# Altium schematic sheet MCU_Debug_COM_Extension.SchDoc(MCU_Debug_COM_Extension)
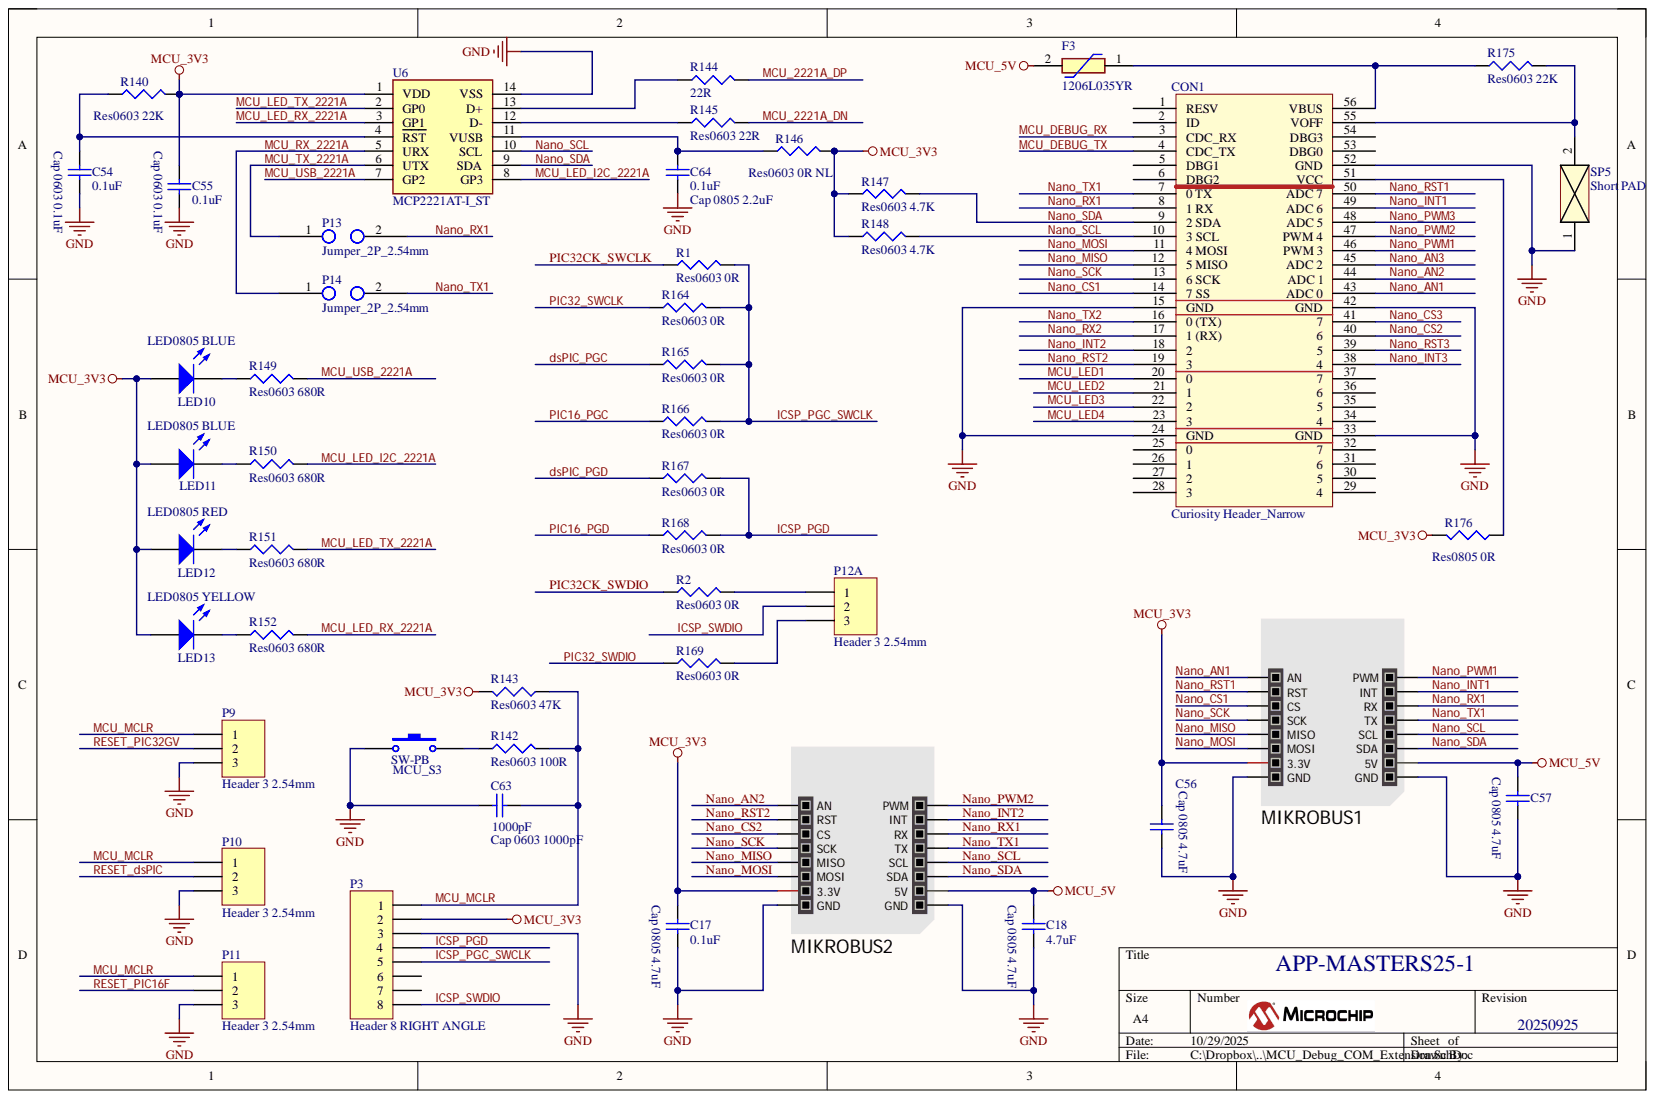
[diagram p0: MCU_Debug_COM_Extension.SchDoc]

## Parts
C17  Cap 0805 4.7uF  value 0.1uF  [C0805]
C18  Cap 0805 4.7uF  value 4.7uF  [C0805]
C54  Cap 0603 0.1uF  value 0.1uF  [0603C]
C55  Cap 0603 0.1uF  value 0.1uF  [0603C]
C56  Cap 0805 4.7uF  value 4.7uF  [C0805]
C57  Cap 0805 4.7uF  value 4.7uF  [C0805]
C63  Cap 0603 1000pF  value 1000pF  [0603C]
C64  Cap 0805 2.2uF  value 0.1uF  [C0805]
CON1  Curiosity Header_Narrow  [Curiosity_Nano_Footprint_Narrow]
F3  1206L035YR  [1206L05015YR]
LED10  LED0805 BLUE  [LED0805_B]
LED11  LED0805 BLUE  [LED0805_B]
LED12  LED0805 RED  [LED0805_R]
LED13  LED0805 YELLOW  [LED0805_Y]
MCU_S3  SW-PB  [Button_SMD]
MIKROBUS1  MIKROBUS HOST CONN._1  [MIKROBUS HOST CONN.]  (value not drawn)
MIKROBUS2  MIKROBUS HOST CONN._1  [MIKROBUS HOST CONN.]  (value not drawn)
P3  Header 8 RIGHT ANGLE  [HEADER-08-RA]
P9  Header 3 2.54mm  [HDR1X3]
P10  Header 3 2.54mm  [HDR1X3]
P11  Header 3 2.54mm  [HDR1X3]
P12A  Header 3 2.54mm  [HDR1X3]
P13  Jumper_2P_2.54mm  [HDR1X2]
P14  Jumper_2P_2.54mm  [HDR1X2]
R1  Res0603 0R  value 0R  [0603R]
R2  Res0603 0R  value 0R  [0603R]
R140  Res0603 22K  value 22K  [0603R]
R142  Res0603 100R  value 100R  [0603R]
R143  Res0603 47K  value 47K  [0603R]
R144  Res0603 22R  value 22R  [0603R]
R145  Res0603 22R  value 22R  [0603R]
R146  Res0603 0R NL  value 0R NL  [0603R]
R147  Res0603 4.7K  value 4.7K  [0603R]
R148  Res0603 4.7K  value 4.7K  [0603R]
R149  Res0603 680R  [0603R]
R150  Res0603 680R  [0603R]
R151  Res0603 680R  [0603R]
R152  Res0603 680R  [0603R]
R164  Res0603 0R  value 0R  [0603R]
R165  Res0603 0R  value 0R  [0603R]
R166  Res0603 0R  value 0R  [0603R]
R167  Res0603 0R  value 0R  [0603R]
R168  Res0603 0R  value 0R  [0603R]
R169  Res0603 0R  value 0R  [0603R]
R175  Res0603 22K  value 22K  [0603R]
R176  Res0805 0R  value 0R  [RES0805]
SP5  Short PAD  [Short_PAD]
U6  MCP2221AT-I_ST  [SOP65P640X120-14N]

## Nets
GND: C17.1 C18.1 C54.1 C55.1 C56.1 C57.1 C63.1 C64.1 CON1.15(GND) CON1.24(GND) CON1.33(GND) CON1.42(GND) CON1.52(GND) MCU_S3.1 MIKROBUS1.8(GND) MIKROBUS1.9(GND) MIKROBUS2.8(GND) MIKROBUS2.9(GND) P3.3 P9.3 P10.3 P11.3 SP5.1 U6.14(VSS)
MCU_3V3: C17.2 C55.2 C56.2 LED10.1 LED11.1 LED12.1 LED13.1 MIKROBUS1.7(3.3V) MIKROBUS2.7(3.3V) P3.2 R140.2 R143.2 R146.2 R147.1 R148.1 R176.2 U6.1(VDD)
MCU_5V: C18.2 C57.2 F3.2 MIKROBUS1.10(5V) MIKROBUS2.10(5V)
dsPIC_PGC: R165.1
dsPIC_PGD: R167.1
ICSP_PGC_SWCLK: P3.5 R1.2 R164.2 R165.2 R166.2
ICSP_PGD: P3.4 R167.2 R168.2
ICSP_SWDIO: P3.8 P12A.2
MCU_2221A_DN: R145.2
MCU_2221A_DP: R144.2
MCU_DEBUG_RX: CON1.3(CDC_RX)
MCU_DEBUG_TX: CON1.4(CDC_TX)
MCU_LED1: CON1.20
MCU_LED2: CON1.21
MCU_LED3: CON1.22
MCU_LED4: CON1.23
MCU_LED_I2C_2221A: R150.2 U6.8(GP3)
MCU_LED_RX_2221A: R152.2 U6.3(GP1)
MCU_LED_TX_2221A: R151.2 U6.2(GP0)
MCU_MCLR: C63.2 P3.1 P9.1 P10.1 P11.1 R142.2 R143.1
MCU_RX_2221A: P14.1 U6.5(URX)
MCU_TX_2221A: P13.1 U6.6(UTX)
MCU_USB_2221A: R149.2 U6.7(GP2)
Nano_AN1: CON1.43(ADC_0) MIKROBUS1.1(AN)
Nano_AN2: CON1.44(ADC_1) MIKROBUS2.1(AN)
Nano_AN3: CON1.45(ADC_2)
Nano_CS1: CON1.14(7_SS) MIKROBUS1.3(CS)
Nano_CS2: CON1.40 MIKROBUS2.3(CS)
Nano_CS3: CON1.41
Nano_INT1: CON1.49(ADC_6) MIKROBUS1.15(INT)
Nano_INT2: CON1.18 MIKROBUS2.15(INT)
Nano_INT3: CON1.38
Nano_MISO: CON1.12(5_MISO) MIKROBUS1.5(MISO) MIKROBUS2.5(MISO)
Nano_MOSI: CON1.11(4_MOSI) MIKROBUS1.6(MOSI) MIKROBUS2.6(MOSI)
Nano_PWM1: CON1.46(PWM_3) MIKROBUS1.16(PWM)
Nano_PWM2: CON1.47(PWM_4) MIKROBUS2.16(PWM)
Nano_PWM3: CON1.48(ADC_5)
Nano_RST1: CON1.50(ADC_7) MIKROBUS1.2(RST)
Nano_RST2: CON1.19 MIKROBUS2.2(RST)
Nano_RST3: CON1.39
Nano_RX1: CON1.8(1_RX) MIKROBUS1.14(RX) MIKROBUS2.14(RX) P13.2
Nano_RX2: CON1.17(1_(RX))
Nano_SCK: CON1.13(6_SCK) MIKROBUS1.4(SCK) MIKROBUS2.4(SCK)
Nano_SCL: CON1.10(3_SCL) MIKROBUS1.12(SCL) MIKROBUS2.12(SCL) R148.2 U6.10(SCL)
Nano_SDA: CON1.9(2_SDA) MIKROBUS1.11(SDA) MIKROBUS2.11(SDA) R147.2 U6.9(SDA)
Nano_TX1: CON1.7(0_TX) MIKROBUS1.13(TX) MIKROBUS2.13(TX) P14.2
Nano_TX2: CON1.16(0_(TX))
PIC16_PGC: R166.1
PIC16_PGD: R168.1
PIC32_SWCLK: R164.1
PIC32_SWDIO: R169.1
PIC32CK_SWCLK: R1.1
PIC32CK_SWDIO: R2.1
RESET_dsPIC: P10.2
RESET_PIC16F: P11.2
RESET_PIC32GV: P9.2
NetC54_2: C54.2 R140.1 U6.4(RST)
NetC64_2: C64.2 R146.1 U6.11(VUSB)
NetCON1_51: CON1.51(VCC) R176.1
NetCON1_55: CON1.55(VOFF) R175.2 SP5.2
NetCON1_56: CON1.56(VBUS) F3.1 R175.1
NetLED10_2: LED10.2 R149.1
NetLED11_2: LED11.2 R150.1
NetLED12_2: LED12.2 R151.1
NetLED13_2: LED13.2 R152.1
NetMCU_S3_2: MCU_S3.2 R142.1
NetP12A_1: P12A.1 R2.2
NetP12A_3: P12A.3 R169.2
NetR144_1: R144.1 U6.13(D+)
NetR145_1: R145.1 U6.12(D-)

Not connected: CON1 1(RESV) 2(ID) 5(DBG1) 6(DBG2) 25 26 27 28 29 30 31 32 34 35 36 37 53(DBG0) 54(DBG3); P3 6 7

## Library data of the parts
C17 C18 C56 C57 C64 = Cap 0805: Capacitor (Semiconductor SIM Model); Component Kind: Standard; LatestRevisionDate: 29-May-2009; LatestRevisionNote: IPC-7351 Footprint Added.; Library Name: MCHP_Parts_2016.SchLib; PackageDescription: Chip Capacitor; PackageReference: C1206; Published: 8-Jun-2000; Publisher: Altium Limited
C54 C55 C63 = Cap 0603: Capacitor (Semiconductor SIM Model); Component Kind: Standard; LatestRevisionDate: 29-May-2009; LatestRevisionNote: IPC-7351 Footprint Added.; Library Name: MCHP_Parts_2016.SchLib; PackageDescription: Chip Capacitor; PackageReference: C0603; Published: 8-Jun-2000; Publisher: Altium Limited
F3 = 1206L035YR: Resettable Fuse; Manufacturer_Name: LITTELFUSE; Arrow Part Number: 1206L035YR; Arrow Price/Stock: https://www.arrow.com/en/products/1206l035yr/littelfuse?region=nac; Component Kind: Standard; Datasheet Link: https://m.littelfuse.com/~/media/electronics/datasheets/resettable_ptcs/littelfuse_ptc_1206l_datasheet.pdf.pdf; Height: 0.75mm; Library Name: SamacSys.SchLib; Manufacturer_Part_Number: 1206L035YR; Mouser Part Number: 576-1206L035YR; Mouser Price/Stock: https://www.mouser.co.uk/ProductDetail/Littelfuse/1206L035YR?qs=PWhpLWeW8wd%2F%252B9d2B1EXww%3D%3D
LED10 LED11 = LED0805 BLUE: Typical BLUE SiC LED; Component Kind: Standard; DatasheetVersion: 16-Apr-2002; LatestRevisionDate: 17-Dec-2003; LatestRevisionNote: PCB Footprint replaced.; Library Name: MCHP_Parts_2016.SchLib; PackageDescription: SM LED; 2 C-Bend Leads; Body 3.5 x 2.8 x 1.9 mm, inc leads (LxWxH typ); PackageReference: 3.5X2.8X1.9; PackageVersion: 16-Apr-2002; Published: 8-Jun-2000; Publisher: Altium Limited
LED12 = LED0805 RED: Typical BLUE SiC LED; Component Kind: Standard; DatasheetVersion: 16-Apr-2002; LatestRevisionDate: 17-Dec-2003; LatestRevisionNote: PCB Footprint replaced.; Library Name: MCHP_Parts_2016.SchLib; PackageDescription: SM LED; 2 C-Bend Leads; Body 3.5 x 2.8 x 1.9 mm, inc leads (LxWxH typ); PackageReference: 3.5X2.8X1.9; PackageVersion: 16-Apr-2002; Published: 8-Jun-2000; Publisher: Altium Limited
LED13 = LED0805 YELLOW: Typical BLUE SiC LED; Component Kind: Standard; DatasheetVersion: 16-Apr-2002; LatestRevisionDate: 17-Dec-2003; LatestRevisionNote: PCB Footprint replaced.; Library Name: MCHP_Parts_2016.SchLib; PackageDescription: SM LED; 2 C-Bend Leads; Body 3.5 x 2.8 x 1.9 mm, inc leads (LxWxH typ); PackageReference: 3.5X2.8X1.9; PackageVersion: 16-Apr-2002; Published: 8-Jun-2000; Publisher: Altium Limited
MCU_S3 = SW-PB: Switch; Component Kind: Standard; LatestRevisionDate: 17-Jul-2002; LatestRevisionNote: Re-released for DXP Platform.; Library Name: MCHP_Parts_2016.SchLib; PackageDescription: Switch; 2 Leads; PackageReference: SPST-2; Published: 24-Mar-1999; Publisher: Altium Limited
MIKROBUS1 MIKROBUS2 = MIKROBUS HOST CONN._1: mikroBUS Host Socket; Component Kind: Standard; Library Name: MCHP_Parts_2016.SchLib; SMD: No
P3 = Header 8 RA: Header, 8-Pin; Component Kind: Standard; LatestRevisionDate: 17-Jul-2002; LatestRevisionNote: Re-released for DXP Platform.; Library Name: MCHP_Parts_2016.SchLib; Publisher: Altium Limited
P9-P11 P12A = Header 3: Header, 3-Pin; Component Kind: Standard; LatestRevisionDate: 17-Jul-2002; LatestRevisionNote: Re-released for DXP Platform.; Library Name: MCHP_Parts_2016.SchLib; Publisher: Altium Limited
R1 R2 R140 R142-R148 R164-R169 R175 = Res0603: Resistor; Component Kind: Standard; LatestRevisionDate: 29-May-2009; LatestRevisionNote: IPC-7351 Footprint Added.; Library Name: MCHP_Parts_2016.SchLib; PackageDescription: Chip Resistor; PackageReference: J1-0603; Published: 8-Jun-2000; Publisher: Altium Limited
R149-R152 = Res0603: Resistor; Value: 680; Component Kind: Standard; LatestRevisionDate: 29-May-2009; LatestRevisionNote: IPC-7351 Footprint Added.; Library Name: MCHP_Parts_2016.SchLib; PackageDescription: Chip Resistor; PackageReference: J1-0603; Published: 8-Jun-2000; Publisher: Altium Limited
R176 = Res0805: Resistor; Component Kind: Standard; LatestRevisionDate: 29-May-2009; LatestRevisionNote: IPC-7351 Footprint Added.; Library Name: MCHP_Parts_2016.SchLib; PackageDescription: Chip Resistor; PackageReference: J1-0603; Published: 8-Jun-2000; Publisher: Altium Limited
SP5 = Short_Pads: Component Kind: Standard; Library Name: MCHP_Parts_2016.SchLib
U6 = MCP2221AT-I_ST: Integrated Circuit; Manufacturer_Name: Microchip; Manufacturer_Part_Number: MCP2221AT-I/ST; Arrow Part Number: MCP2221AT-I/ST; Arrow Price/Stock: https://www.arrow.com/en/products/mcp2221at-ist/microchip-technology?utm_currency=USD&region=nac; Component Kind: Standard; Datasheet Link: http://www.microchip.com/mymicrochip/filehandler.aspx?ddocname=en586514; Height: 1.2mm; Mouser Part Number: 579-MCP2221AT-I/ST; Mouser Price/Stock: https://www.mouser.co.uk/ProductDetail/Microchip-Technology/MCP2221AT-I-ST?qs=ZEMkBHnybRFglbHs%252Blub6Q%3D%3D
CON1 = Curiosity Header_Narrow: Component Kind: Standard; Library Name: MCHP_Parts_2016.SchLib
P13 P14 = Jumper_2P_2.54mm: Component Kind: Standard; Library Name: MCHP_Parts_2016.SchLib
